# Altium schematic sheet Regulator.SchDoc
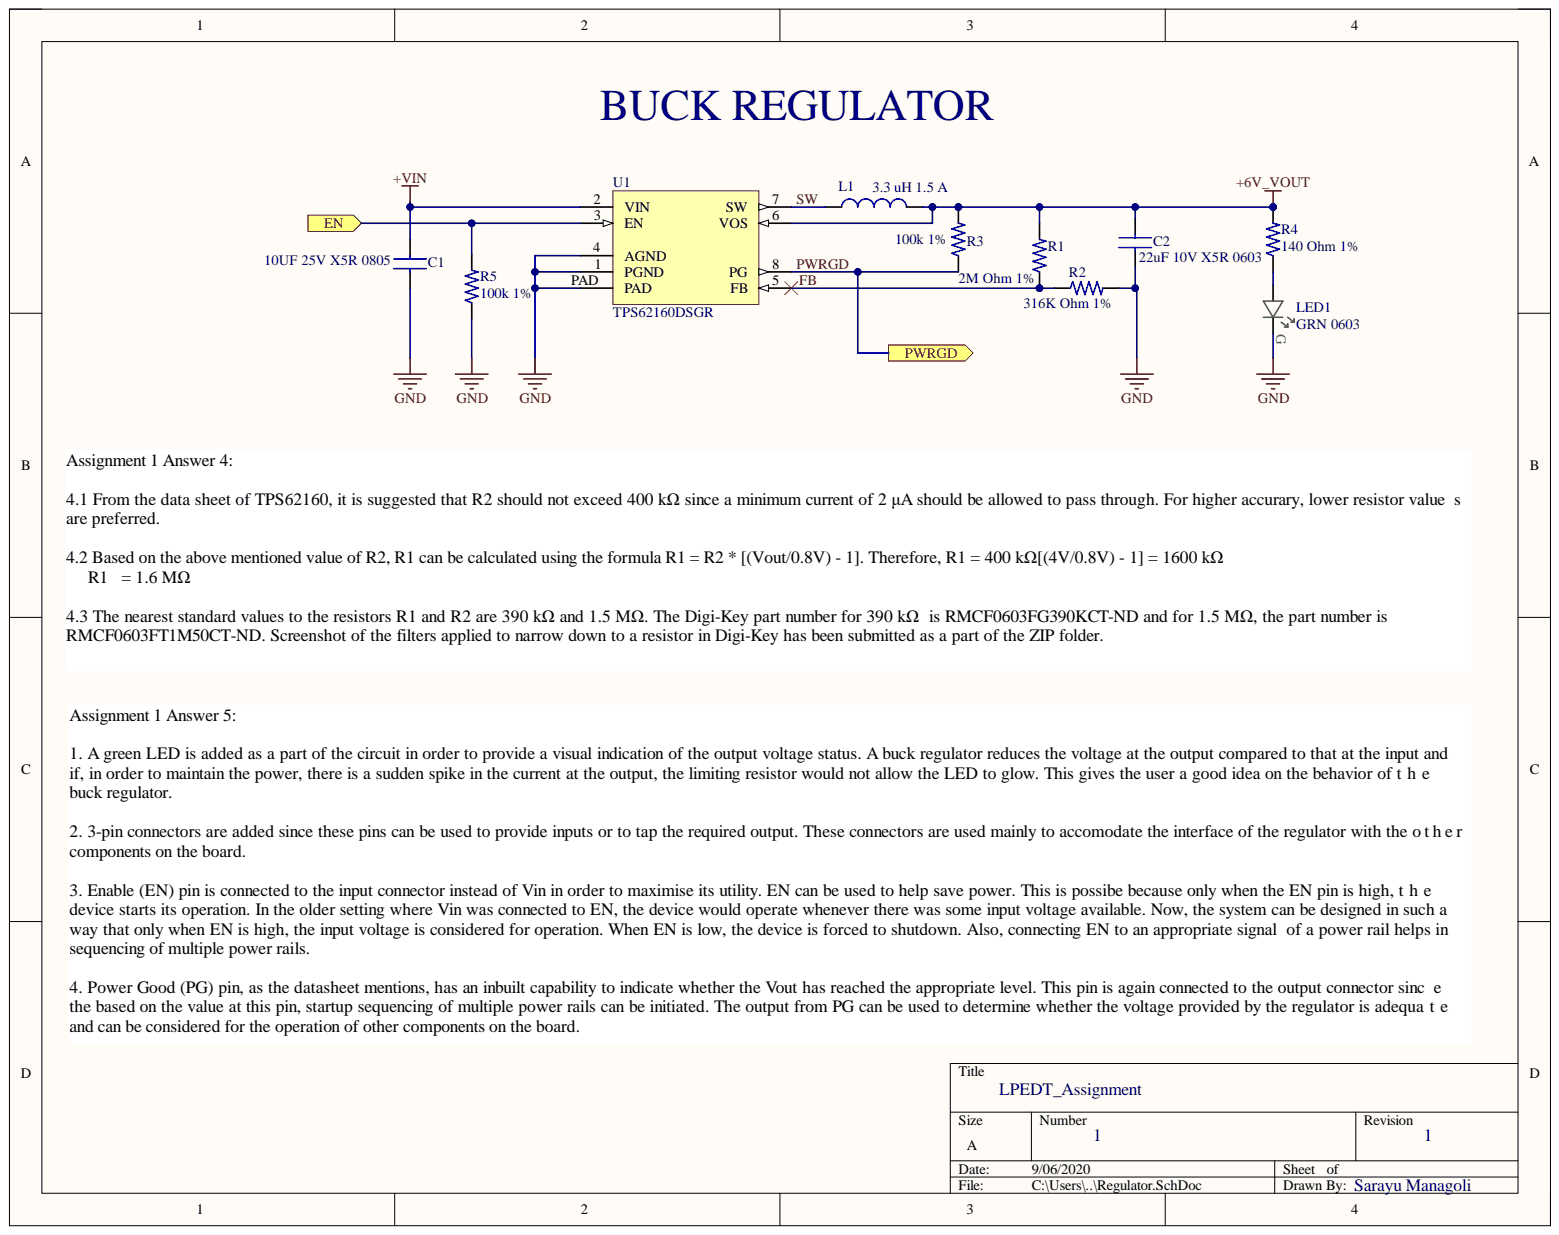
[diagram p0: Regulator.SchDoc]

## Parts
C1  10UF 25V X5R 0805  Case/Package: 0805  [CAP_2012X14]
C2  22uF 10V X5R 0603  [CAP_0603_1MM]
L1  3.3 uH 1.5 A  [IND_2828x30]
LED1  GRN 0603  [LED_0603_GRN_WL-SMCW]
R1  2M Ohm 1%  Tolerance: 1%  [RES_0603_1608X06]
R2  316K Ohm 1%  Tolerance: 1%  [RES_0603_1608X06]
R3  100k 1%  [RES_0603_1608X06]
R4  140 Ohm 1%  Case Code (Imperial): 0603  [RES_0603_1608X06]
R5  100k 1%  [RES_0603_1608X06]
U1  TPS62160DSGR  [8-DFN_2x2]

## Ports
EN, PWRGD

## Nets
+6V_VOUT: C2.1 L1.2 R1.2 R3.2 R4.2 U1.6(VOS)
+VIN: C1.1 U1.2(VIN)
GND: C1.2 C2.2 LED1.C R2.2 R5.1 U1.1(PGND) U1.4(AGND) U1.PAD
EN: R5.2 U1.3(EN) ; port EN
FB: R1.1 R2.1 U1.5(FB)
PWRGD: R3.1 U1.8(PG) ; port PWRGD
SW: L1.1 U1.7(SW)
NetLED1_A: LED1.A R4.1

## Library data of the parts
C1 = GRM21BR61E106KA73K: CAP CER 10UF 25V X5R 0805; Manufacturer: Murata; Tolerance: 10%; Capacitance: 10uF; Category: Ceramic Capacitors; Component Kind: Standard; ComponentLink1Description: http://www.mouser.com/ds/2/281/c02e-2905.pdf?src-supplier=Mouser; ComponentLink1URL: http://www.mouser.com/ds/2/281/c02e-2905.pdf?src-supplier=Mouser; ComponentLink2Description: http://search.murata.co.jp/Ceramy/image/img/A01X/G101/ENG/GRM21BR61E106KA73-01.pdf?src-supplier=Digi-Key; ComponentLink2URL: http://search.murata.co.jp/Ceramy/image/img/A01X/G101/ENG/GRM21BR61E106KA73-01.pdf?src-supplier=Digi-Key; ComponentLink3Description: https://search.murata.co.jp/Ceramy/image/img/A01X/G101/ENG/GRM21BR61E106KA73-01.pdf?src-supplier=Digi-Key; ComponentLink3URL: https://search.murata.co.jp/Ceramy/image/img/A01X/G101/ENG/GRM21BR61E106KA73-01.pdf?src-supplier=Digi-Key; Dielectric: X5R; Length: 0.079inch; Library Name: LPEDT_Parts.IntLib; Manufacturer Part Number: GRM21BR61E106KA73K; Max Operating Temperature: 85°C; Min Operating Temperature: -55°C; Mount: Surface Mount; Package Quantity: 10000; Packaging: Tape and Reel; Pricing 1: 1=0.25000, 10=0.17200, 50=0.10340, 100=0.08740, 500=0.05978, 1000=0.05288, 2500=0.05058, 5000=0.04598 (USD); RoHS: Yes; Stock 1: 51226; Supplier 1: Digi-Key; Thickness: 0.055inch; Voltage Rating: 25V; Voltage Rating (DC): 25V; Weight: 0.000335oz; Width: 0.049inch
C2 = GRM188R61A226ME15D: CAP CER 22UF 10V X5R 0603; Manufacturer: Murata; Brand: Murata Electronics; Tolerance: 20 %; Type: Voltage and Temperature Derating Recommended Product; Unit Weight: 0.000222 oz; Voltage Rating DC: 10 VDC; Capacitance: 22 uF; Case Code - in: 0603; Case Code - mm: 1608; Component Kind: Standard; ComponentLink1Description: http://www.farnell.com/datasheets/2047978.pdf?src-supplier=Element14; ComponentLink1URL: http://www.farnell.com/datasheets/2047978.pdf?src-supplier=Element14; Dielectric: X5R; Factory Pack Quantity: 4000; Height: 0.8 mm; Length: 1.6 mm; Library Name: LPEDT_Parts.IntLib; Manufacturer Part Number: GRM188R61A226ME15D; Maximum Operating Temperature: + 85 C; Minimum Operating Temperature: - 55 C; Package / Case: 0603 (1608 metric); Packaging: Reel; Pricing 1: 1=0.33, 10=0.226, 50=0.1506, 100=0.1279, 500=0.0903, 1000=0.079 (USD); Product: General Type MLCCs; Product Category: Multilayer Ceramic Capacitors MLCC - SMD/SMT; RoHS: True; Series: GRM; Stock 1: 849458; Supplier 1: Digi-Key; Supplier Part Number 1: 490-10476-1-ND; Termination Style: SMD/SMT; Width: 0.8 mm
L1 = 744025003: WURTH ELEKTRONIK - 744025003 - Leistungsinduktivität (SMD), 3.3 µH, 1.5 A, Geschirmt, 1.8 A, Baureihe WE-TPC; Manufacturer: Wurth Electronics; Core Material: Ferrite; Current Rating: 1.5A; DC Resistance (DCR): 101mR; Inductance: 3.3uH; Inductance Tolerance: 20%; Saturation Current: 1.8A; Self Resonant Frequency: 100MHz; Series Resistance: 85MR; Shielding: Shielded; Test Frequency: 100kHz; Case/Package: Nonstandard; Category: Fixed Inductors; Component Kind: Standard; ComponentLink1Description: https://datasheet.ciiva.com/11803/0900766b80f886b5-11803594.pdf?src-supplier=RSComponents; ComponentLink1URL: https://datasheet.ciiva.com/11803/0900766b80f886b5-11803594.pdf?src-supplier=RSComponents; ComponentLink2Description: https://datasheet.ciiva.com/1060/744025003-1060566.pdf?src-supplier=Digi-Key; ComponentLink2URL: https://datasheet.ciiva.com/1060/744025003-1060566.pdf?src-supplier=Digi-Key; ComponentLink3Description: https://datasheet.ciiva.com/4954/744025003-88791-4954796.pdf?src-supplier=Mouser; ComponentLink3URL: https://datasheet.ciiva.com/4954/744025003-88791-4954796.pdf?src-supplier=Mouser; ComponentLink4Description: https://datasheet.ciiva.com/14123/744025003-eng-tds-14123829.pdf?src-supplier=Distrelec; ComponentLink4URL: https://datasheet.ciiva.com/14123/744025003-eng-tds-14123829.pdf?src-supplier=Distrelec; ComponentLink5Description: https://datasheet.ciiva.com/1060/744025003-1060567.pdf?src-supplier=Digi-Key; ComponentLink5URL: https://datasheet.ciiva.com/1060/744025003-1060567.pdf?src-supplier=Digi-Key; ComponentLink6Description: https://datasheet.ciiva.com/1060/744025003-1060568.pdf?src-supplier=Digi-Key; ComponentLink6URL: https://datasheet.ciiva.com/1060/744025003-1060568.pdf?src-supplier=Digi-Key; Depth: 2.8mm; Height: 2.8mm; Height - Seated (Max): 0.118inch; Length: 2.8mm; Library Name: LPEDT_Parts.IntLib; Manufacturer Part Number: 744025003; Max DC Current: 1.5A; Max Operating Temperature: 125°C; Min Operating Temperature: -40°C; Mount: Surface Mount; Number of Pins: 2; Package Quantity: 1; Packaging: Cut Tape; Pricing 1: 1=1.54000, 10=1.48000, 50=1.36000, 100=1.13000, 250=1.02000 (USD); REACH SVHC: Unknown; Resistor Type: Wirewound; RMS Current (Irms): 1.5A; RoHS: Yes; Stock 1: 2583; Supplier 1: Digi-Key; Termination: SMD/SMT; Tolerance: 20%; Width: 0.11inch
LED1 = 150060GS75000: LED, 0603, GREEN, 430MCD, 520NM; Manufacturer: Wurth Electronics; 3D Model: SMCW_0603.igs    SMCW_0603.stp    SMCW_0603.wrl; Current - Test: 20mA; Dynamic Catalog: 0603 Rectangle with Flat Top Chip LEDs; Lens Style/Size: Rectangle with Flat Top, 1.1mm x 0.7mm; Lens Type: Clear; Other Names: 732-4971-2; Viewing Angle: 140°; Voltage - Forward (Vf) (Typ): 3.2V; Wavelength - Peak: 515nm; Category: Optoelectronics; Color: Green; Component Kind: Standard; ComponentLink1Description: https://katalog.we-online.de/led/datasheet/150060GS75000.pdf?src-supplier=Digi-Key; ComponentLink1URL: https://katalog.we-online.de/led/datasheet/150060GS75000.pdf?src-supplier=Digi-Key; ComponentLink2Description: http://www.produktinfo.conrad.com/datenblaetter/750000-774999/759427-da-01-en-CHIPLED_0603_W_KL_GRUEN_150060GS75000.pdf?src-supplier=Conrad; ComponentLink2URL: http://www.produktinfo.conrad.com/datenblaetter/750000-774999/759427-da-01-en-CHIPLED_0603_W_KL_GRUEN_150060GS75000.pdf?src-supplier=Conrad; Family: LED Indication - Discrete; Height: 0.70mm; Library Name: LPEDT_Parts.IntLib; Manufacturer Part Number: 150060GS75000; Millicandela Rating: 430mcd; Mounting Type: Surface Mount; Online Catalog: 0603 Rectangle with Flat Top Chip LEDs; Package / Case: 0603 (1608 Metric); Packaging: Tape & Reel (TR); Pricing 1: 1=0.14, 50=0.129, 100=0.11, 500=0.095 (USD); Series: WL-SMCW; Size / Dimension: 1.60mm L x 0.80mm W; Standard Package: 4,000; Stock 1: 34964; Supplier 1: Digi-Key; Supplier Part Number 1: 732-4971-1-ND; Wavelength - Dominant: 520nm
R1 = RC0603FR-072ML: Res Thick Film 0603 2M Ohm 1% 0.1W(1/10W) +/-100ppm/ßC Epoxy SMD T/R; Manufacturer: Yageo; Max Power Dissipation: 100mW; Resistance: 2MR; Temperature Coefficient: 100ppm/°C; Voltage Rating: 75V; Voltage Rating (DC): 50V; Case Code (Imperial): 0603; Case Code (Metric): 1608; Case/Package: 0603; Category: Chip SMD Resistors; Component Kind: Standard; ComponentLink1Description: https://datasheet.ciiva.com/11808/0900766b80f96399-11808743.pdf?src-supplier=RSComponents; ComponentLink1URL: https://datasheet.ciiva.com/11808/0900766b80f96399-11808743.pdf?src-supplier=RSComponents; ComponentLink2Description: https://datasheet.ciiva.com/2454/pyu-r-int-thick-7-2454115.pdf?src-supplier=Digi-Key; ComponentLink2URL: https://datasheet.ciiva.com/2454/pyu-r-int-thick-7-2454115.pdf?src-supplier=Digi-Key; ComponentLink3Description: https://datasheet.ciiva.com/2454/pyu-r-int-thick-7-2454116.pdf?src-supplier=Digi-Key; ComponentLink3URL: https://datasheet.ciiva.com/2454/pyu-r-int-thick-7-2454116.pdf?src-supplier=Digi-Key; ComponentLink4Description: https://datasheet.ciiva.com/2454/pyu-r-int-thick-7-2454114.pdf?src-supplier=Digi-Key; ComponentLink4URL: https://datasheet.ciiva.com/2454/pyu-r-int-thick-7-2454114.pdf?src-supplier=Digi-Key; Depth: 0.8mm; Features: Moisture Resistant; Height: 0.45mm; Lead Free: Lead Free; Length: 1.6mm; Library Name: LPEDT_Parts.IntLib; Manufacturer Part Number: RC0603FR-072ML; Max Operating Temperature: 155°C; Min Operating Temperature: -55°C; Mount: Surface Mount; Number of Terminations: 2; Package Quantity: 1; Packaging: Tape and Reel; Power Rating: 100mW; Pricing 1: 1=0.10000, 10=0.02200, 100=0.00900, 1000=0.00405, 2500=0.00352 (USD); Resistor Type: Thick Film; RoHS: Yes; Stock 1: 594795; Supplier 1: Digi-Key; Termination: SMD/SMT; Weight: 7.1E-05oz; Width: 0.8mm
R2 = RC0603FR-0731K6L: Res Thick Film 0603 31.6K Ohm 1% 0.1W(1/10W) ?100ppm/?C Molded SMD Paper T/R; Manufacturer: Yageo; Max Power Dissipation: 100mW; Resistance: 31.6kR; Temperature Coefficient: 100ppm/°C; Voltage Rating: 50V; Working Voltage: 75V; Case Code (Imperial): 0603; Case Code (Metric): 1608; Case/Package: 0603; Category: Chip SMD Resistors; Component Kind: Standard; ComponentLink1Description: https://datasheet.ciiva.com/15189/getdatasheetpartid-687536-15189700.pdf?src-supplier=Verical; ComponentLink1URL: https://datasheet.ciiva.com/15189/getdatasheetpartid-687536-15189700.pdf?src-supplier=Verical; ComponentLink2Description: https://datasheet.ciiva.com/2455/pyu-r-int-thick-7-2455125.pdf?src-supplier=Digi-Key; ComponentLink2URL: https://datasheet.ciiva.com/2455/pyu-r-int-thick-7-2455125.pdf?src-supplier=Digi-Key; ComponentLink3Description: https://datasheet.ciiva.com/15189/getdatasheetpartid-329296-15189701.pdf?src-supplier=Verical; ComponentLink3URL: https://datasheet.ciiva.com/15189/getdatasheetpartid-329296-15189701.pdf?src-supplier=Verical; ComponentLink4Description: https://datasheet.ciiva.com/2455/pyu-r-int-thick-7-2455126.pdf?src-supplier=Digi-Key; ComponentLink4URL: https://datasheet.ciiva.com/2455/pyu-r-int-thick-7-2455126.pdf?src-supplier=Digi-Key; ComponentLink5Description: https://datasheet.ciiva.com/2455/pyu-r-int-thick-7-2455127.pdf?src-supplier=Digi-Key; ComponentLink5URL: https://datasheet.ciiva.com/2455/pyu-r-int-thick-7-2455127.pdf?src-supplier=Digi-Key; Depth: 0.8mm; Features: Moisture Resistant; Height: 0.022inch; Lead Free: Lead Free; Length: 1.6mm; Library Name: LPEDT_Parts.IntLib; Manufacturer Part Number: RC0603FR-0731K6L; Max Operating Temperature: 155°C; Military Standard: Not; Min Operating Temperature: -55°C; Number of Terminations: 2; Package Quantity: 1; Packaging: Tape and Reel; Power Rating: 100mW; Pricing 1: 1=0.10000, 10=0.02200, 100=0.00900, 1000=0.00405, 2500=0.00352 (USD); Resistor Type: Thick Film; RoHS: Yes; Stock 1: 121296; Supplier 1: Digi-Key; Termination: SMD/SMT; Voltage Rating (DC): 50V; Width: 0.8mm
R3 R5 = RC0603FR-07100KL: RES SMD 100K OHM 1% 1/10W 0603; Manufacturer: Yageo; Power Rating: 100 mW; Resistance: 100 kOhms; Temperature Coefficient: 100 PPM / C; Voltage Rating: 50 V; Case Code - in: 0603; Case Code - mm: 1608; Component Kind: Standard; ComponentLink1Description: http://www.farnell.com/datasheets/2167052.pdf?src-supplier=Element14; ComponentLink1URL: http://www.farnell.com/datasheets/2167052.pdf?src-supplier=Element14; ComponentLink2Description: http://www.farnell.com/datasheets/1868787.pdf?src-supplier=Element14; ComponentLink2URL: http://www.farnell.com/datasheets/1868787.pdf?src-supplier=Element14; ComponentLink3Description: http://documents.rs-components.com/techlib://itc_doclist_GB.htm?stockno=5048940&src-supplier=RSComponents; ComponentLink3URL: http://documents.rs-components.com/techlib://itc_doclist_GB.htm?stockno=5048940&src-supplier=RSComponents; ComponentLink4Description: http://www.farnell.com/datasheets/443971.pdf?src-supplier=Element14; ComponentLink4URL: http://www.farnell.com/datasheets/443971.pdf?src-supplier=Element14; Library Name: LPEDT_Parts.IntLib; Manufacturer Part Number: RC0603FR-07100KL; Operating Temperature Range: - 55 C to + 155 C; Packaging: Reel; Pricing 1: 1=0.1, 10=0.022, 100=0.009, 1000=0.0041, 2500=0.0035 (USD); Product: Thick Film Resistors General Purpose; Series: RC; Stock 1: 13478446; Supplier 1: Digi-Key; Supplier Part Number 1: 311-100KHRCT-ND; Termination Style: SMD/SMT; Tolerance: 1 %
R4 = RC0603FR-07140RL: Thick Film Resistors 140 OHM 1%; Manufacturer: Yageo; Power Rating: 100mW; Resistance: 140R; Temperature Coefficient: 100ppm/°C; Voltage Rating: 50V; Case Code (Metric): 1608; Case/Package: 0603; Category: Chip SMD Resistors; Component Kind: Standard; ComponentLink1Description: https://datasheet.ciiva.com/11808/0900766b80c66297-11808828.pdf?src-supplier=RSComponents; ComponentLink1URL: https://datasheet.ciiva.com/11808/0900766b80c66297-11808828.pdf?src-supplier=RSComponents; ComponentLink2Description: https://datasheet.ciiva.com/15189/getdatasheetpartid-329205-15189606.pdf?src-supplier=Verical; ComponentLink2URL: https://datasheet.ciiva.com/15189/getdatasheetpartid-329205-15189606.pdf?src-supplier=Verical; Depth: 0.8mm; Height: 0.45mm; Lead Free: Lead Free; Length: 1.6mm; Library Name: LPEDT_Parts.IntLib; Manufacturer Part Number: RC0603FR-07140RL; Max Operating Temperature: 155°C; Military Standard: Not; Min Operating Temperature: -55°C; Mount: Surface Mount; Packaging: Tape and Reel; Pricing 1: 1=0.10000, 10=0.02200, 100=0.00900, 1000=0.00405, 2500=0.00352 (USD); Resistor Type: Thick Film; RoHS: Yes; Stock 1: 606987; Supplier 1: Digi-Key; Termination: SMD/SMT; Tolerance: 1%; Voltage Rating (DC): 50V
U1 = TPS62160DSGR: IC REG BUCK ADJ 1A SYNC 8WSON; Manufacturer: Texas Instruments; Max Input Voltage: 17V; Max Output Voltage: 6V; Min Input Voltage: 3V; Min Output Voltage: 900mV; Number of Outputs: 1; Output Configuration: Positive; Output Type: Adjustable; Quiescent Current: 17uA; Switching Frequency: 2.25MHz; Synchronous Rectifier: Yes; Case/Package: SOIC; Category: Voltage Regulators - Switching; Component Kind: Standard; ComponentLink1Description: https://datasheet.ciiva.com/2026/tps62161-2026049.pdf?src-supplier=Digi-Key; ComponentLink1URL: https://datasheet.ciiva.com/2026/tps62161-2026049.pdf?src-supplier=Digi-Key; ComponentLink2Description: https://datasheet.ciiva.com/2026/tps62160-2026414.pdf?src-supplier=Digi-Key; ComponentLink2URL: https://datasheet.ciiva.com/2026/tps62160-2026414.pdf?src-supplier=Digi-Key; ComponentLink3Description: https://datasheet.ciiva.com/2026/tps62160-2026415.pdf?src-supplier=Digi-Key; ComponentLink3URL: https://datasheet.ciiva.com/2026/tps62160-2026415.pdf?src-supplier=Digi-Key; Contact Plating: Gold; Lead Free: Lead Free; Library Name: LPEDT_Parts.IntLib; Manufacturer Part Number: TPS62160DSGR; Max Operating Temperature: 125°C; Max Output Current: 1A; Min Operating Temperature: -40°C; Number of Pins: 8; Operating Supply Voltage: 17V; Output Current: 1A; Output Voltage: 6V; Package Quantity: 3000; Packaging: Tape and Reel; Pricing 1: 1=1.59000, 10=1.43100, 25=1.35000, 100=1.08000, 250=0.94500, 500=0.91800, 1000=0.72900 (USD); Radiation Hardening: No; REACH SVHC: No SVHC; RoHS: Yes; Stock 1: 51561; Supplier 1: Digi-Key
